# Altium schematic sheet RS422 Breakout.SchDoc
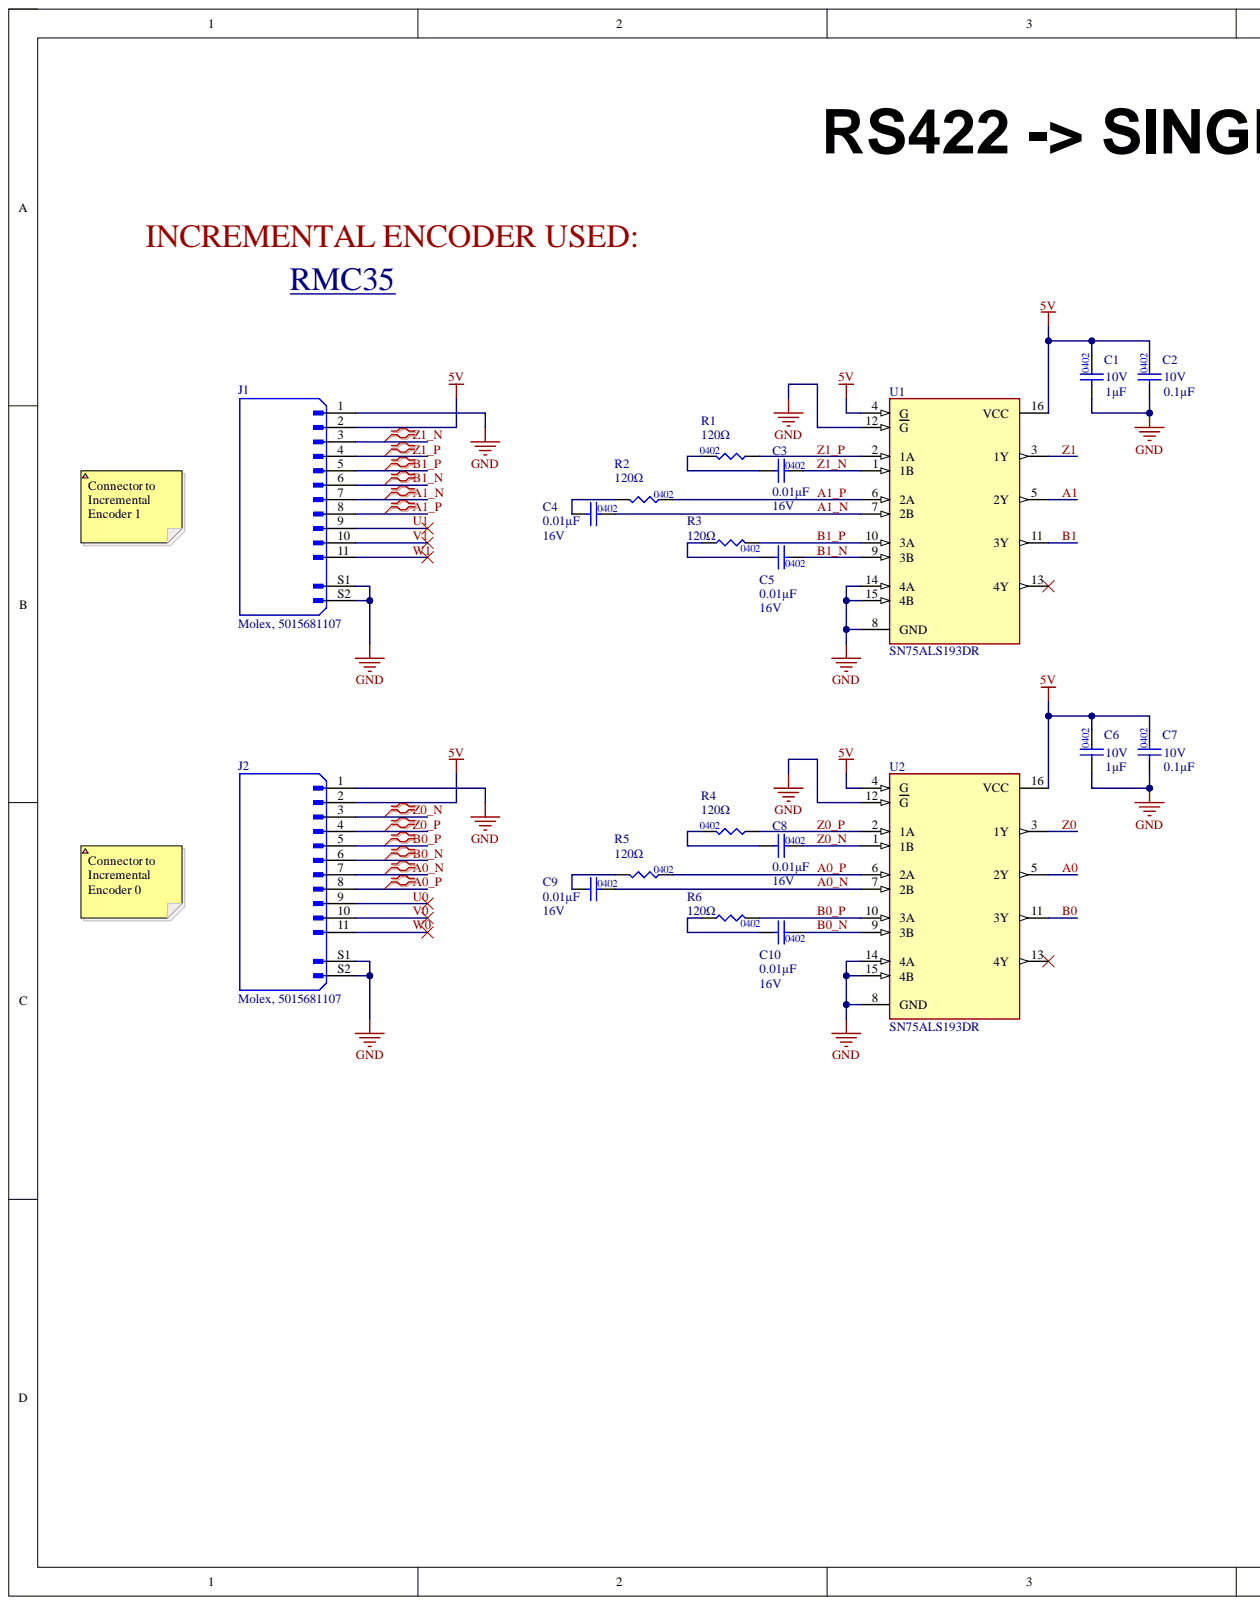
[diagram p0: RS422 Breakout.SchDoc, left]
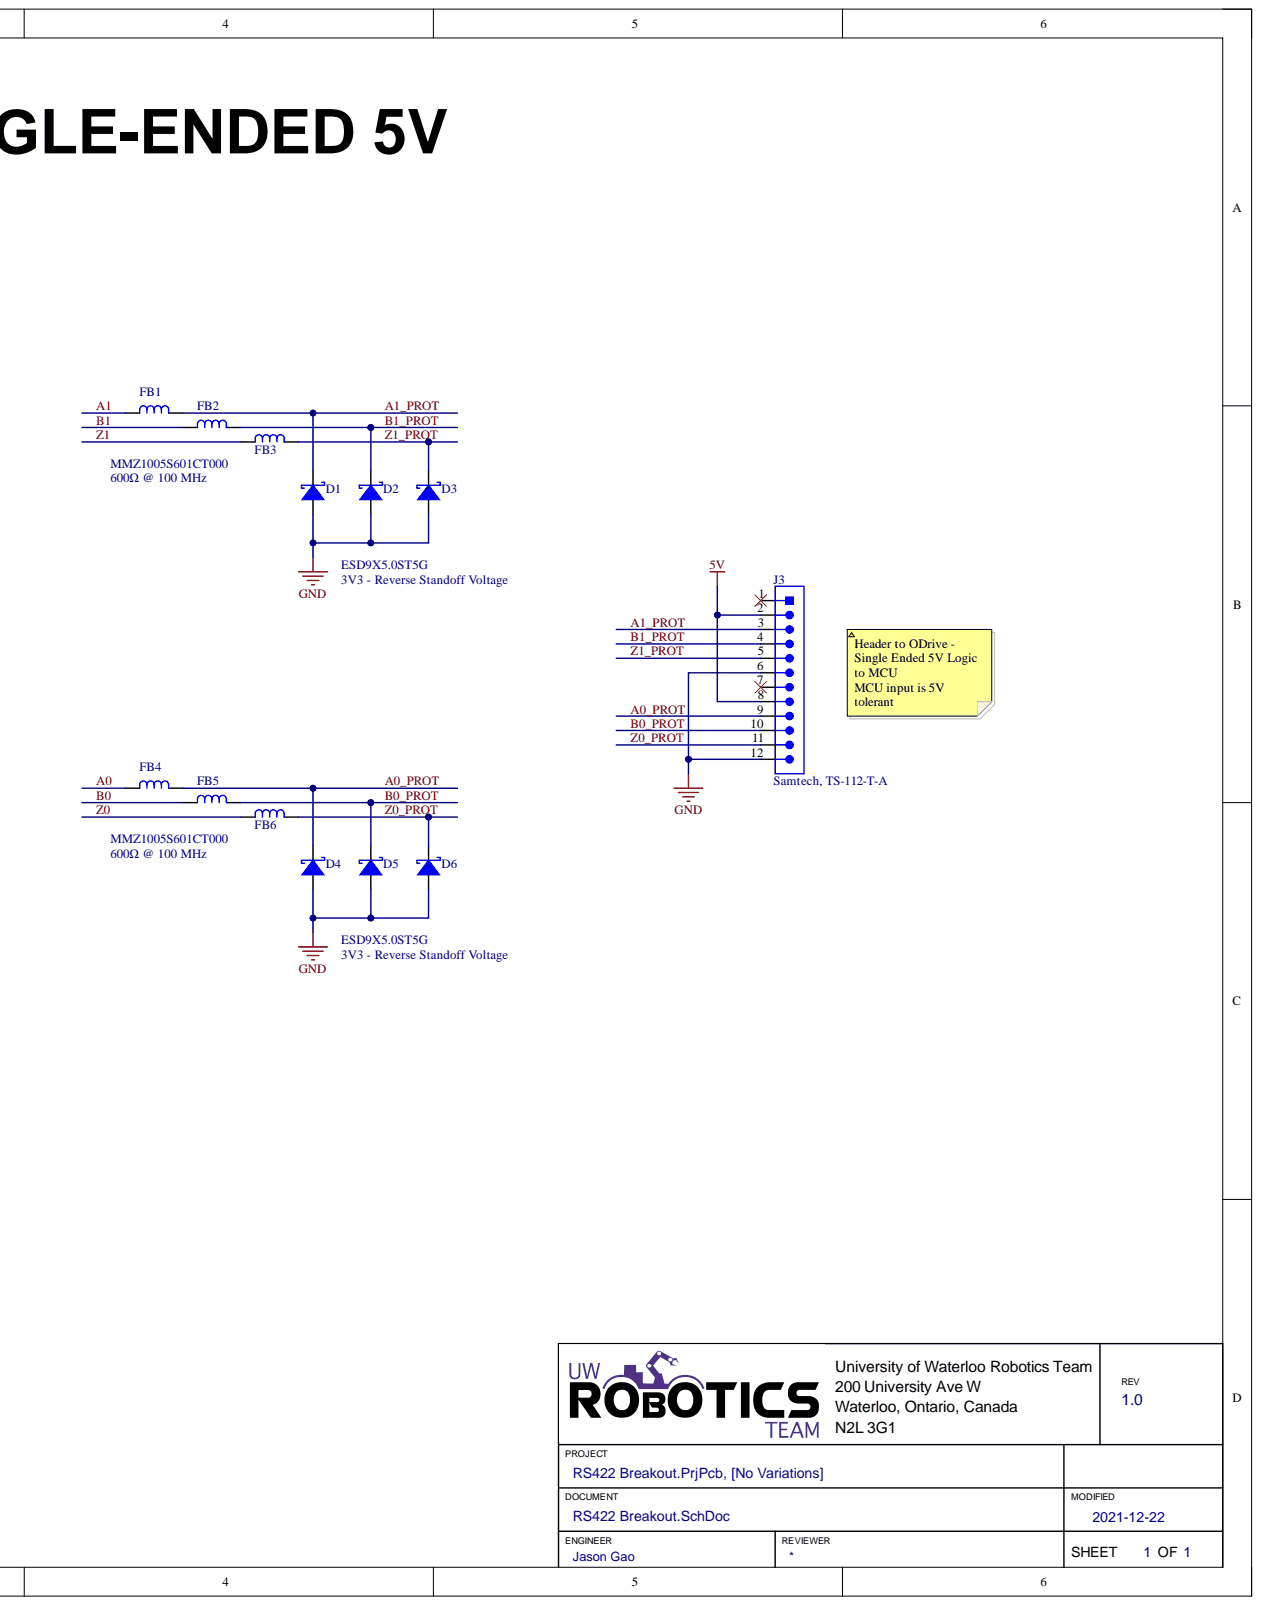
[diagram p1: RS422 Breakout.SchDoc, right]

## Parts
C1  Capacitance: 1μF  Package: 0402  Rated DC Voltage (URdc): 10V  [CAP0402]  (hidden comment: CAP_1UF_10V_0402_X5R)
C2  value 0.1µF  Package/Footprint: 0402  Voltage Rating: 10V  [CAP0402]  (hidden comment: CAP_0.1uF_10V_0402)
C3  Capacitance: 0.01μF  Voltage Rating: 16V  [CAP0402]  (hidden comment: CAP_0.01uF_16V_X7R_0402)
C4  Capacitance: 0.01μF  Voltage Rating: 16V  [CAP0402]  (hidden comment: CAP_0.01uF_16V_X7R_0402)
C5  Capacitance: 0.01μF  Voltage Rating: 16V  [CAP0402]  (hidden comment: CAP_0.01uF_16V_X7R_0402)
C6  Capacitance: 1μF  Package: 0402  Rated DC Voltage (URdc): 10V  [CAP0402]  (hidden comment: CAP_1UF_10V_0402_X5R)
C7  value 0.1µF  Package/Footprint: 0402  Voltage Rating: 10V  [CAP0402]  (hidden comment: CAP_0.1uF_10V_0402)
C8  Capacitance: 0.01μF  Voltage Rating: 16V  [CAP0402]  (hidden comment: CAP_0.01uF_16V_X7R_0402)
C9  Capacitance: 0.01μF  Voltage Rating: 16V  [CAP0402]  (hidden comment: CAP_0.01uF_16V_X7R_0402)
C10  Capacitance: 0.01μF  Voltage Rating: 16V  [CAP0402]  (hidden comment: CAP_0.01uF_16V_X7R_0402)
D1  TVS_DIODE_UNIDIR_5V  [sod-923]  (value not drawn)
D2  TVS_DIODE_UNIDIR_5V  [sod-923]  (value not drawn)
D3  TVS_DIODE_UNIDIR_5V  [sod-923]  (value not drawn)
D4  TVS_DIODE_UNIDIR_5V  [sod-923]  (value not drawn)
D5  TVS_DIODE_UNIDIR_5V  [sod-923]  (value not drawn)
D6  TVS_DIODE_UNIDIR_5V  [sod-923]  (value not drawn)
FB1  FERRITE_BEAD_600OHM_0402  [RES0402]  (value not drawn)
FB2  FERRITE_BEAD_600OHM_0402  [RES0402]  (value not drawn)
FB3  Manufacturer Part Number 1: MMZ1005S601CT000  [RES0402]  (hidden comment: FERRITE_BEAD_600OHM_0402)
FB4  FERRITE_BEAD_600OHM_0402  [RES0402]  (value not drawn)
FB5  Manufacturer Part Number 1: MMZ1005S601CT000  [RES0402]  (hidden comment: FERRITE_BEAD_600OHM_0402)
FB6  Manufacturer Part Number 1: MMZ1005S601CT000  [RES0402]  (hidden comment: FERRITE_BEAD_600OHM_0402)
J1  MP: Molex, 5015681107  [MOLEX-5015681107]  (hidden comment: CON_12M_SM_RA)
J2  MP: Molex, 5015681107  [MOLEX-5015681107]  (hidden comment: CON_12M_SM_RA)
J3  Samtech, TS-112-T-A  [Samtech-TS-112-T-A]
R1  value 120Ω  Package/Footprint: 0402  [RES0402]  (hidden comment: RES_120Ω_0402_5%)
R2  value 120Ω  Package/Footprint: 0402  [RES0402]  (hidden comment: RES_120Ω_0402_5%)
R3  value 120Ω  Package/Footprint: 0402  [RES0402]  (hidden comment: RES_120Ω_0402_5%)
R4  value 120Ω  Package/Footprint: 0402  [RES0402]  (hidden comment: RES_120Ω_0402_5%)
R5  value 120Ω  Package/Footprint: 0402  [RES0402]  (hidden comment: RES_120Ω_0402_5%)
R6  value 120Ω  Package/Footprint: 0402  [RES0402]  (hidden comment: RES_120Ω_0402_5%)
U1  SN75ALS193DR  [SOIC127P600X175-16N]
U2  SN75ALS193DR  [SOIC127P600X175-16N]

## Nets
5V: C1.2 C2.2 C6.2 C7.2 J1.2 J2.2 J3.2 J3.8 U1.4(G) U1.16(VCC) U2.4(G) U2.16(VCC)
GND: C1.1 C2.1 C6.1 C7.1 D1.2 D2.2 D3.2 D4.2 D5.2 D6.2 J1.1 J1.S1 J1.S2 J2.1 J2.S1 J2.S2 J3.6 J3.12 U1.8(GND) U1.12(G) U1.14(4A) U1.15(4B) U2.8(GND) U2.12(G) U2.14(4A) U2.15(4B)
A0: FB4.1 U2.5(2Y)
A0_N: C9.2 J2.7 U2.7(2B)
A0_P: J2.8 R5.2 U2.6(2A)
A0_PROT: D4.1 FB4.2 J3.9
A1: FB1.1 U1.5(2Y)
A1_N: C4.2 J1.7 U1.7(2B)
A1_P: J1.8 R2.2 U1.6(2A)
A1_PROT: D1.1 FB1.2 J3.3
B0: FB5.1 U2.11(3Y)
B0_N: C10.2 J2.6 U2.9(3B)
B0_P: J2.5 R6.2 U2.10(3A)
B0_PROT: D5.1 FB5.2 J3.10
B1: FB2.1 U1.11(3Y)
B1_N: C5.2 J1.6 U1.9(3B)
B1_P: J1.5 R3.2 U1.10(3A)
B1_PROT: D2.1 FB2.2 J3.4
U0: J2.9
U1: J1.9
V0: J2.10
V1: J1.10
W0: J2.11
W1: J1.11
Z0: FB6.1 U2.3(1Y)
Z0_N: C8.2 J2.3 U2.1(1B)
Z0_P: J2.4 R4.1 U2.2(1A)
Z0_PROT: D6.1 FB6.2 J3.11
Z1: FB3.1 U1.3(1Y)
Z1_N: C3.2 J1.3 U1.1(1B)
Z1_P: J1.4 R1.1 U1.2(1A)
Z1_PROT: D3.1 FB3.2 J3.5
NetC3_1: C3.1 R1.2
NetC4_1: C4.1 R2.1
NetC5_1: C5.1 R3.1
NetC8_1: C8.1 R4.2
NetC9_1: C9.1 R5.1
NetC10_1: C10.1 R6.1

Not connected: J3 1 7; U1 13(4Y); U2 13(4Y)

## Library data of the parts
C1 C6 = CAP_1UF_10V_0402_X5R: CAP CER 1UF 10V X5R 0402; Manufacturer: Kyocera AVX; Manufacturer Part Number: 0402ZD105MAT2A; Component Kind: Standard; ComponentLink7Description: https://datasheet.ciiva.com/11531/cx5r-11531784.pdf?src-supplier=Avnet; ComponentLink7URL: https://datasheet.ciiva.com/11531/cx5r-11531784.pdf?src-supplier=Avnet; Mounting Type: Surface Mount; Supplier 1: Digi-Key; Supplier Part Number 1: 478-5768-1-ND; Temperature Characteristics Code: X5R; Library Name: UWRT_Schematic_Library_2020.SchLib
C2 C7 = CAP_0.1uF_10V_0402: CAP CER 0.1UF 10V X7R 0402; Manufacturer 1: Murata Electronics; Manufacturer Part Number 1: GRM155R71A104KA01D; Component Kind: Standard; Supplier 1: Digi-Key; Supplier Part Number 1: 490-6321-1-ND; Library Name: UWRT_Schematic_Library_2020.SchLib
C3-C5 C8-C10 = CAP_0.01uF_16V_X7R_0402: CAP CER 0.01uF 16V X7R 0402; Manufacturer: KEMET; Manufacturer Part Number: C0402C103J4RACTU; Component Kind: Standard; Mounting Type: Surface Mount; Supplier 1: Digi-Key; Supplier Part Number 1: 399-1039-1-ND; Library Name: UWRT_Schematic_Library_2020.SchLib
D1 D4 = TVS_DIODE_UNIDIR_5V: TVS DIODE 5VWM 12.3VC SOD923; Manufacturer 1: ON Semiconductor; Manufacturer Part Number 1: ESD9X5.0ST5G; Clamping Voltage-Max: 12.3V; Min Breakdown Voltage: 6.2V; Component Kind: Standard; Supplier 1: Digi-Key; Supplier Part Number 1: ESD9X5.0ST5GOSCT-ND; Library Name: UWRT_Schematic_Library_2020.SchLib
D2 D3 D5 D6 = TVS_DIODE_UNIDIR_5V: TVS DIODE 5VWM 12.3VC SOD923; Manufacturer 1: ON Semiconductor; Clamping Voltage-Max: 12.3V; Min Breakdown Voltage: 6.2V; Component Kind: Standard; Supplier 1: Digi-Key; Supplier Part Number 1: ESD9X5.0ST5GOSCT-ND; Library Name: UWRT_Schematic_Library_2020.SchLib
FB1 FB2 FB4 = FERRITE_BEAD_600OHM_0402: FIXED IND 1UH 3.8A 33 MOHM SMD; Manufacturer 1: TDK Corporation; Manufacturer Part Number 1: MMZ1005S601CT000; Current Rating (Max): 300mA; DC Resistance (DCR) (Max): 520mΩ; Impedance: 600Ω; Impedance @ Frequency: 600Ω @ 100 MHz; Component Kind: Standard; Mounting Type: SMD; Package: 0402; Package/Footprint: SMD; Supplier 1: Digi-Key; Supplier Part Number 1: 445-2162-1-ND; Library Name: UWRT_Schematic_Library_2020.SchLib
FB3 FB5 FB6 = FERRITE_BEAD_600OHM_0402: FIXED IND 1UH 3.8A 33 MOHM SMD; Manufacturer 1: TDK Corporation; Current Rating (Max): 300mA; DC Resistance (DCR) (Max): 520mΩ; Impedance: 600Ω; Impedance @ Frequency: 600Ω @ 100 MHz; Component Kind: Standard; Mounting Type: SMD; Package: 0402; Package/Footprint: SMD; Supplier 1: Digi-Key; Supplier Part Number 1: 445-2162-1-ND; Library Name: UWRT_Schematic_Library_2020.SchLib
J1 J2 = CON_11M_SM_RA: CONN HEADER SMD RIGHT ANGLE 1MM PITCH; Availability: Unavailable; Component Kind: Standard; Package: None; Price: None; Supplier 1: Digi-Key; Supplier Part Number 1: WM7902CT-ND; Library Name: templocal.SchLib
J3 = CON_12M_TH_VERT_2.54mm: CONN HEADER THROUGH HOLE VERTICAL 2.54MM PITCH; Component Kind: Standard; Manufacturing Part Number: Samtech, TS-112-T-A; Supplier 1: Digi-Key; Supplier Part Number 1: SAM12216-ND; Library Name: UWRT_Schematic_Library_2020.SchLib
R1-R6 = RES_120Ω_0402_5%: RES 120 OHM 5% 1/16W 0402; Manufacturer 1: Yageo; Manufacturer Part Number 1: RC0402JR-07120RL; Component Kind: Standard; Mounting Type: SMD; Power: 1/16W; Supplier 1: Digi-Key; Supplier Part Number 1: 311-120JRCT-ND; Tolerance: 5%; Library Name: UWRT_Schematic_Library_2020.SchLib
U1 U2 = SN75ALS193DR: 0/4 Receiver - RS422, RS423 16-SOIC; Mfr_Name: Texas Instruments; Component Kind: Standard; Manufacturer_Part_Number: SN75ALS193DR; RefDes: RefDes; Supplier 1: Digi-Key; Supplier Part Number 1: 296-24362-1-ND; Type: DEV; Copyright: Copyright (C) 2021 Ultra Librarian. All rights reserved.; Library Name: UWRT_Schematic_Library_2020.SchLib
